# Altium schematic sheet 07 - BLE.SchDoc
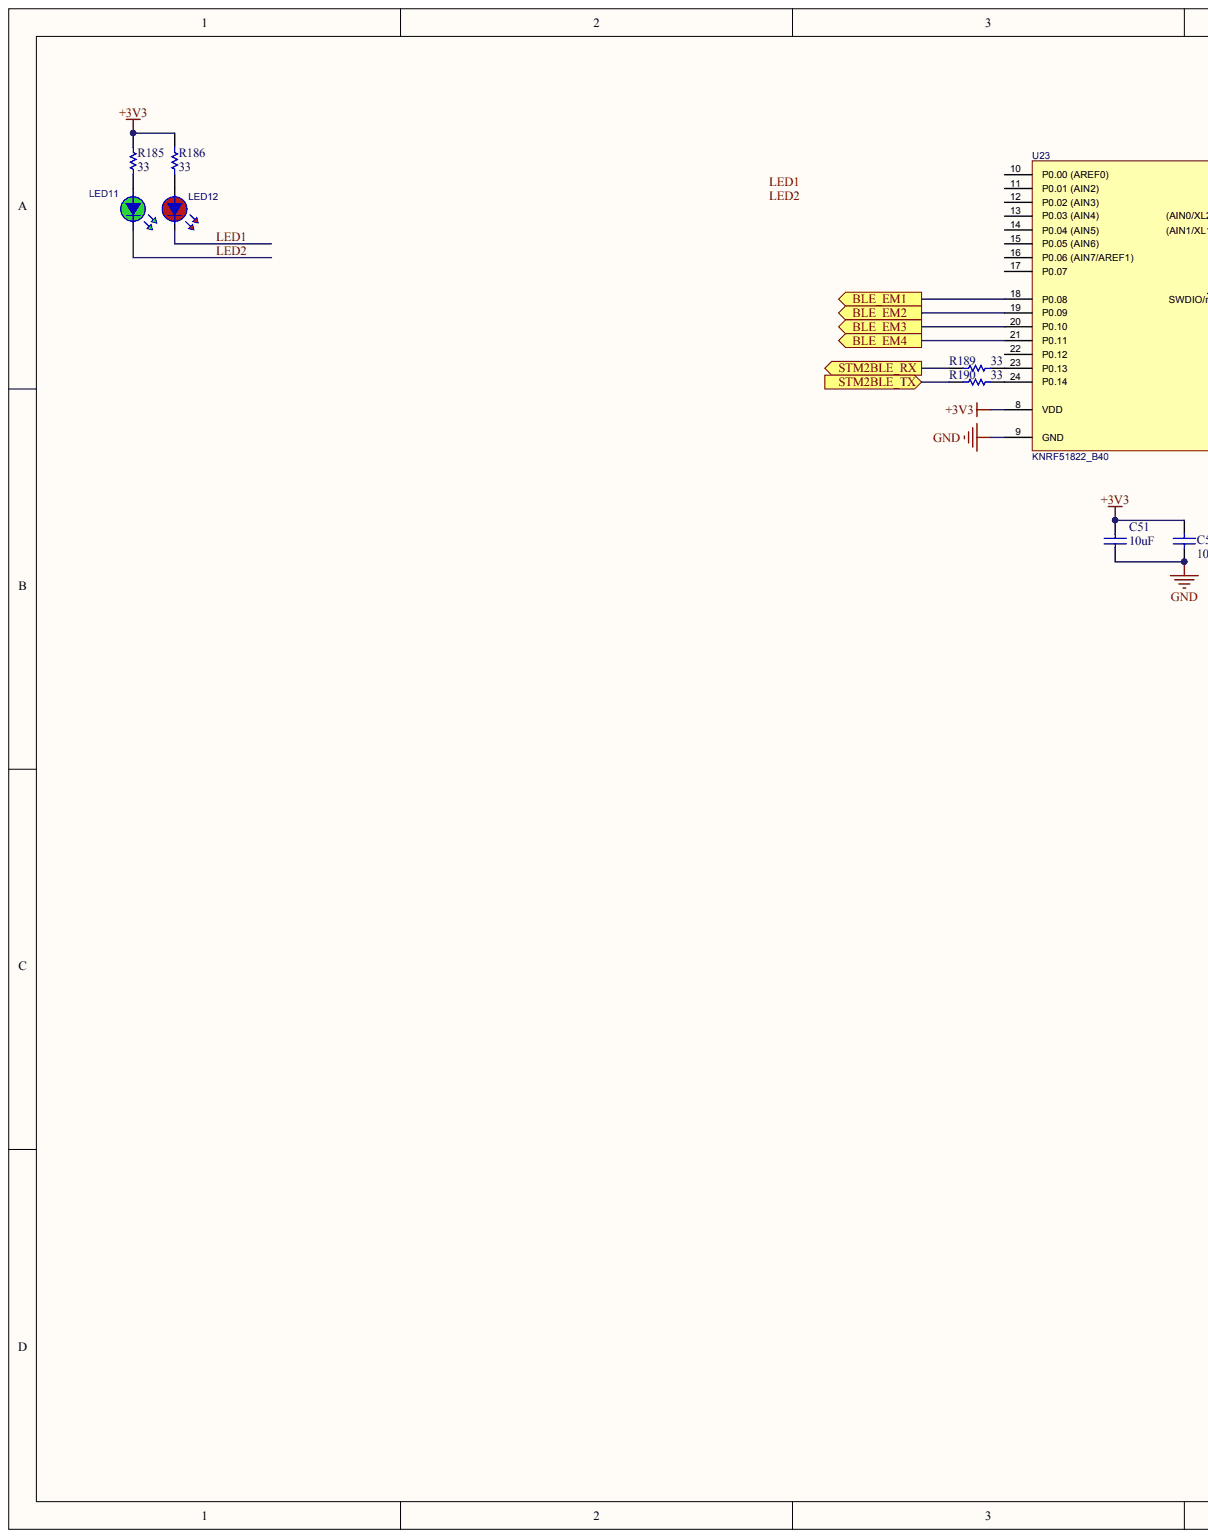
[diagram p0: 07 - BLE.SchDoc, left]
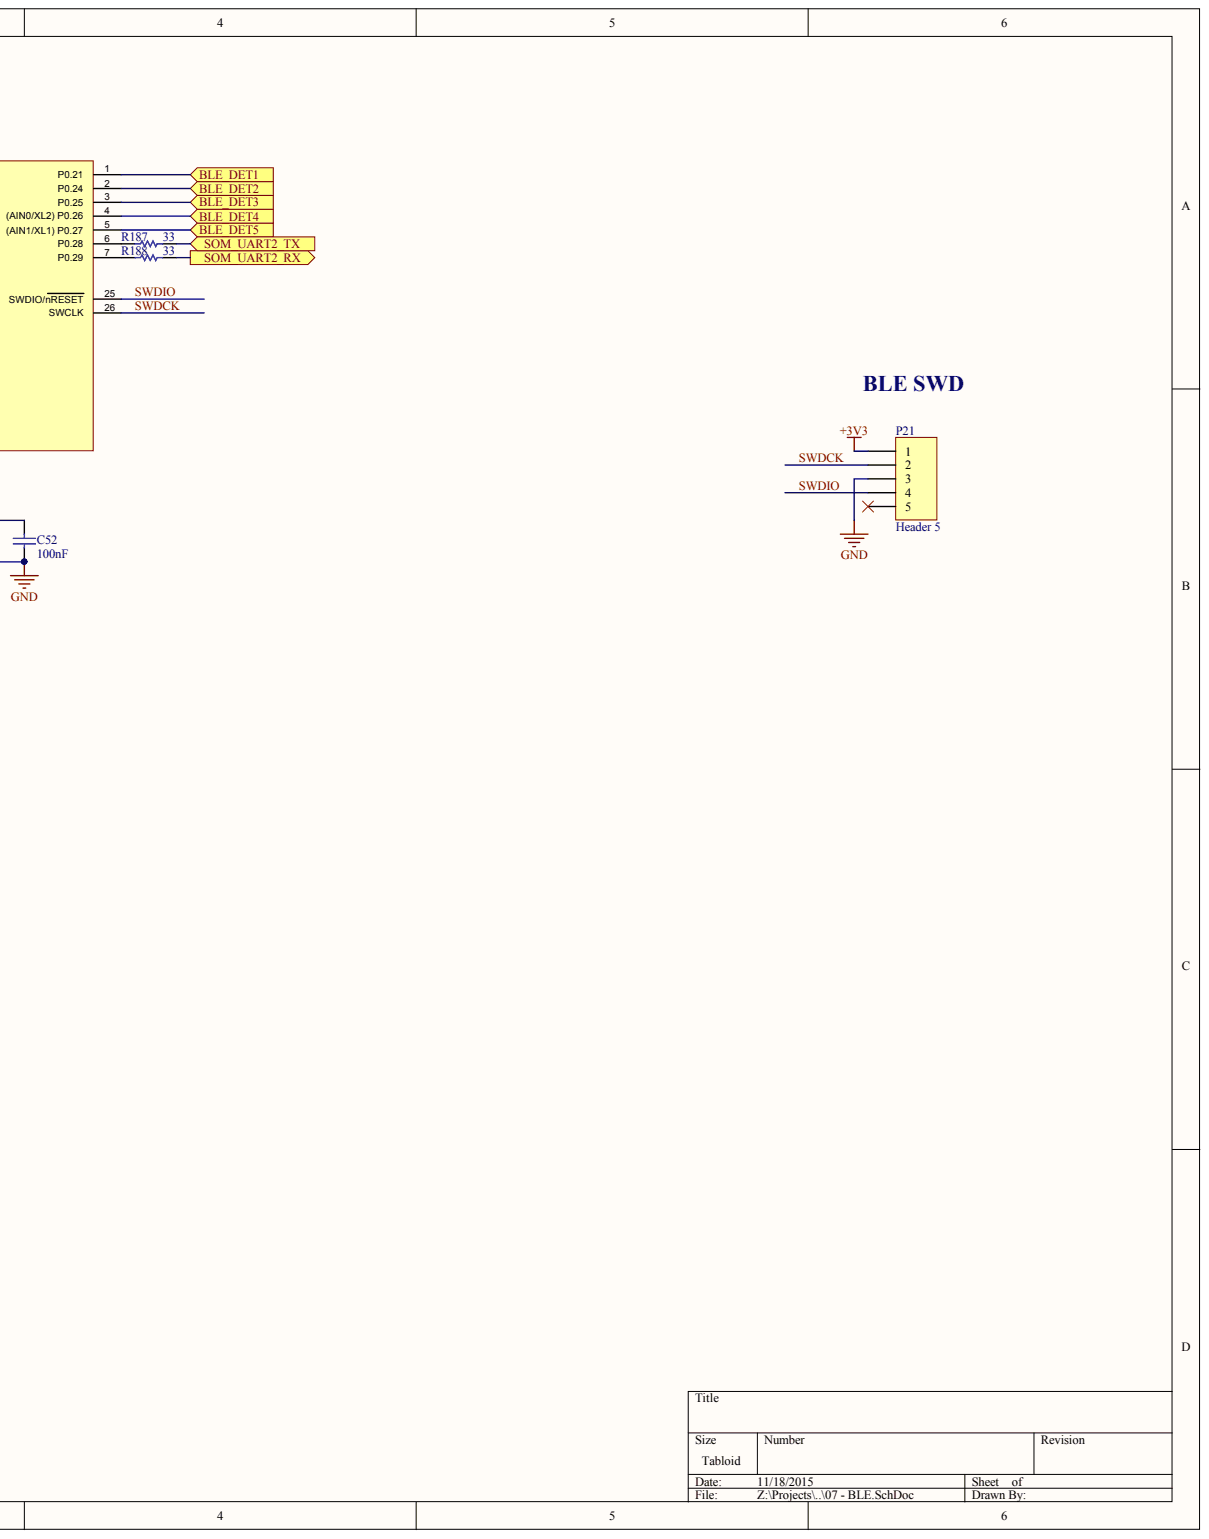
[diagram p1: 07 - BLE.SchDoc, right]

## Parts
C51  value 10uF  [CAPC0805(2012)100_N]  (hidden comment: CAP 10uF 6.3V 0805(2012))
C52  value 100nF  [CAPC0805(2012)100_N]  (hidden comment: CAP 100nF 6.3V 0805(2012))
LED11  VLMG1500-GS08  [LEDC0402(1005)_N-GRN]  (value not drawn)
LED12  VLMS1500-GS08  [LEDC0402(1005)_N-RED]  (value not drawn)
P21  Header 5  [HDR1X5]
R185  value 33  [RESC0805(2012)_M]
R186  value 33  [RESC0805(2012)_M]
R187  value 33  [RESC0805(2012)_M]
R188  value 33  [RESC0805(2012)_M]
R189  value 33  [RESC0805(2012)_M]
R190  value 33  [RESC0805(2012)_M]
U23  KNRF51822_B40  [MOD_KnRF51822_B40]

## Ports
BLE_DET1, BLE_DET2, BLE_DET3, BLE_DET4, BLE_DET5, BLE_EM1, BLE_EM2, BLE_EM3, BLE_EM4, SOM_UART2_RX, SOM_UART2_TX, STM2BLE_RX, STM2BLE_TX

## Nets
+3V3: C51.2 C52.1 P21.1 R185.1 R186.1 U23.8(VDD)
GND: C51.1 C52.2 P21.3 U23.9(GND)
BLE_DET1: U23.1(P0.21) ; port BLE_DET1
BLE_DET2: U23.2(P0.24) ; port BLE_DET2
BLE_DET3: U23.3(P0.25) ; port BLE_DET3
BLE_DET4: U23.4((AIN0/XL2)_P0.26) ; port BLE_DET4
BLE_DET5: U23.5((AIN1/XL1)_P0.27) ; port BLE_DET5
BLE_EM1: U23.18(P0.08) ; port BLE_EM1
BLE_EM2: U23.19(P0.09) ; port BLE_EM2
BLE_EM3: U23.20(P0.10) ; port BLE_EM3
BLE_EM4: U23.21(P0.11) ; port BLE_EM4
LED1: LED12.K
LED2: LED11.K
SOM_UART2_RX: R188.1 ; port SOM_UART2_RX
SOM_UART2_TX: R187.1 ; port SOM_UART2_TX
STM2BLE_RX: R189.2 ; port STM2BLE_RX
STM2BLE_TX: R190.2 ; port STM2BLE_TX
SWDCK: P21.2 U23.26(SWCLK)
SWDIO: P21.4 U23.25(SWDIO/nRESET)
NetLED11_A: LED11.A R185.2
NetLED12_A: LED12.A R186.2
NetR187_2: R187.2 U23.6(P0.28)
NetR188_2: R188.2 U23.7(P0.29)
NetR189_1: R189.1 U23.23(P0.13)
NetR190_1: R190.1 U23.24(P0.14)

Not connected: P21 5; U23 10(P0.00_(AREF0)) 11(P0.01_(AIN2)) 12(P0.02_(AIN3)) 13(P0.03_(AIN4)) 14(P0.04_(AIN5)) 15(P0.05_(AIN6)) 16(P0.06_(AIN7/AREF1)) 17(P0.07) 22(P0.12)

## Library data of the parts
C51 = CMP-1036-04920-1: CAP 10uF 6.3V Â±20% 0805 (2012 Metric) Thickness 1mm SMD; Case-EIA: 0805; Case-Metric: 2012; Component Kind: Standard; Library Name: Altium Inc., Content Vault; Max Thickness: 1 mm; Rated Voltage: 6.3 V; Technology: SMT; Tolerance: Â±20%
C52 = CMP-1036-04391-1: CAP 100nF 6.3V Â±10% 0805 (2012 Metric) Thickness 1mm SMD; Case-EIA: 0805; Case-Metric: 2012; Component Kind: Standard; Library Name: Altium Content Vault; Max Thickness: 1 mm; Rated Voltage: 6.3 V; Technology: SMT; Tolerance: Â±10%
LED11 = LED_0402_VLMG1500-GS08: LED GREEN 0402 SMD; Manufacturer: Vishay Semiconductor Opto Division; Current - Test: 20mA; Lens Style/Size: Rectangle with Flat Top, 1.00mm x 0.50mm; Millicandela Rating: 35mcd; Viewing Angle: 130°; Voltage - Forward (Vf) (Typ): 2V; Wavelength - Dominant: 571nm; Wavelength - Peak: 574nm; Category: Optoelectronics; Color: Green; Component Kind: Standard; ComponentLink1Description: http://www.vishay.com/docs/82554/vlmo1500.pdf; ComponentLink1URL: http://www.vishay.com/docs/82554/vlmo1500.pdf; Height (Max): 0.35mm; Lens Color: -; Lens Transparency: -; Library Name: RevAir32.IntLib; Manufacturer Part Number: VLMG1500-GS08; Mounting Type: Surface Mount; Package / Case: 0402 (1005 Metric); Packaging: Cut Tape (CT); Pricing 1: 1=0.52, 10=0.384, 25=0.3072, 100=0.2035, 250=0.144, 500=0.12288, 750=0.10368, 1000=0.096 (USD); RoHS: RoHS Compliant; Size / Dimension: 1.00mm L x 0.50mm W; Stock 1: 37065; Supplier 1: Digi-Key; Supplier Device Package: 0402; Supplier Part Number 1: VLMG1500-GS08CT-ND
LED12 = LED_0402_VLMS1500-GS08: LED RED 0402 SMD; Manufacturer: Vishay Semiconductor Opto Division; Current - Test: 20mA; Lens Style/Size: Rectangle with Flat Top, 1.00mm x 0.50mm; Millicandela Rating: 54mcd; Viewing Angle: 130°; Voltage - Forward (Vf) (Typ): 2V; Wavelength - Dominant: 631nm; Wavelength - Peak: 639nm; Category: Optoelectronics; Color: Red; Component Kind: Standard; ComponentLink1Description: http://www.vishay.com/docs/82554/vlmo1500.pdf; ComponentLink1URL: http://www.vishay.com/docs/82554/vlmo1500.pdf; Height (Max): 0.35mm; Lens Color: -; Lens Transparency: -; Library Name: RevAir32.IntLib; Manufacturer Part Number: VLMS1500-GS08; Mounting Type: Surface Mount; Package / Case: 0402 (1005 Metric); Packaging: Cut Tape (CT); Pricing 1: 1=0.52, 10=0.384, 25=0.3072, 100=0.2035, 250=0.144, 500=0.12288, 750=0.10368, 1000=0.096 (USD); RoHS: RoHS Compliant; Size / Dimension: 1.00mm L x 0.50mm W; Stock 1: 41406; Supplier 1: Digi-Key; Supplier Device Package: 0402; Supplier Part Number 1: VLMS1500-GS08CT-ND
P21 = Header 5: Header, 5-Pin; Component Kind: Standard; LatestRevisionDate: 17-Jul-2002; LatestRevisionNote: Re-released for DXP Platform.; Library Name: Miscellaneous Connectors.IntLib; Signal Integrity: Connector; Publisher: Altium Limited
R185-R190 = CMP-1013-00623-1: 10K 0.125W 1% 0805 (2012 Metric)  SMD; Case-EIA: 0805; Case-Metric: 2012; Component Kind: Standard; Library Name: Altium Inc., Content Vault; Power: 0.125 W; Technology: SMT; Tolerance: 1 %
U23 = RF_KNRF51822_B40: BLE / MULTI-PROTOCOL 2.4GHz RF MODULE; Manufacturer: NST; Antenna: PCB Antenna; Core IC: Nordic nRF51822; Current consumption: 11.8mA typ; Frequency range: 2400 – 2483.5 MHz; PCB Size: 13.5mm x 16.2mm; Rx sensitivity: -93 dBm typ.; Supply Voltage: 1.8 - 3.6V; Tx power: 4 dBm; Component Kind: Standard; Library Name: RevAir32.IntLib; Manufacturer Part Number: KNRF51822_B40; Operating temperature: -25 to +75°C
